# Altium schematic sheet [11]-JTAG.SchDoc
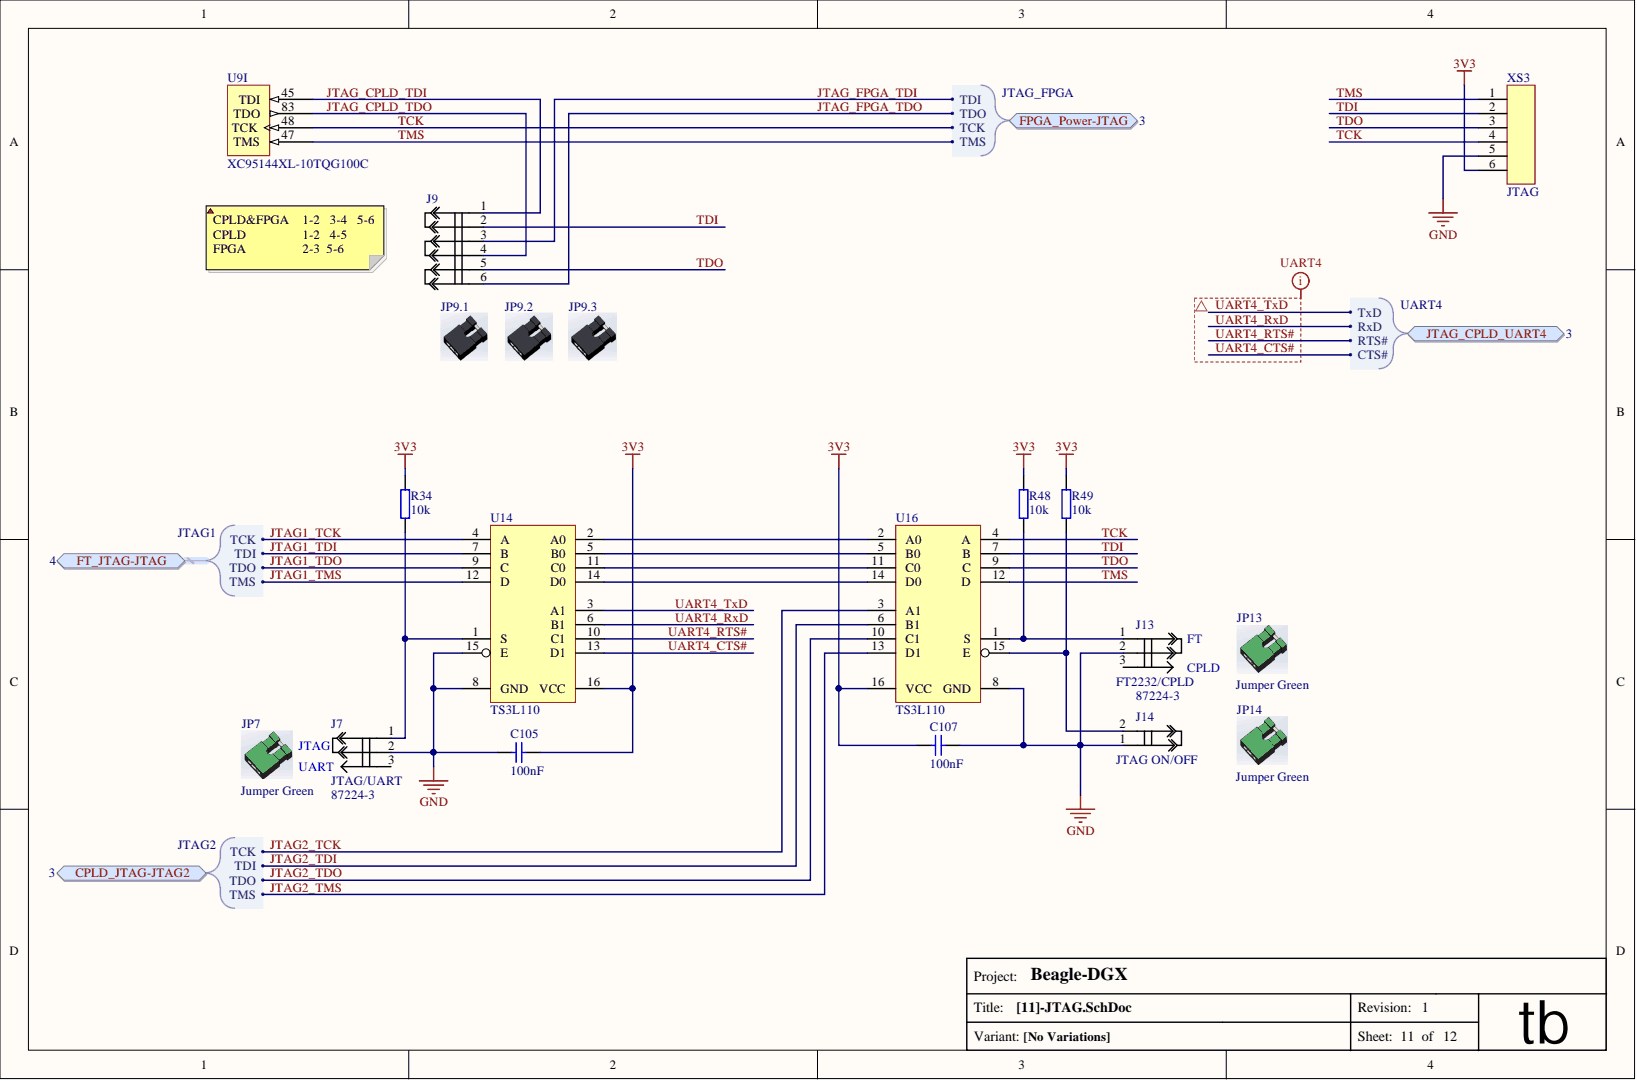
[diagram p0: [11]-JTAG.SchDoc]

## Parts
C105  value 100nF  [C0603]  (hidden comment: CAP)
C107  value 100nF  [C0603]  (hidden comment: CAP)
J7  JTAG/UART  value 87224-3  Manufacturer Part: 87224-3  [Jumper_3pin_green]
J9  jumper_6pin_3closed  [Jumper_6pin_3Closed_Black]  (value not drawn)
J13  FT2232/CPLD  value 87224-3  [Jumper_3pin_green]
J14  JTAG ON/OFF  [Jumper_2pin green]
JP7  value Jumper Green  Supplier Part: Jumper  (hidden comment: VCC_sel)  (mechanical)
JP9.1  (no value)  (mechanical)
JP9.2  (no value)  (mechanical)
JP9.3  (no value)  (mechanical)
JP13  value Jumper Green  Supplier Part: Jumper  (hidden comment: VCC_sel)  (mechanical)
JP14  value Jumper Green  Supplier Part: Jumper  (hidden comment: VCC_sel)  (mechanical)
R34  value 10k  [0603_RESISTOR]  (hidden comment: Resistor)
R48  value 10k  [0603_RESISTOR]  (hidden comment: Resistor)
R49  value 10k  [0603_RESISTOR]  (hidden comment: Resistor)
U9  XC95144XL-10TQG100C  [TQ100 - XC95144XL]  (part I)
U14  TS3L110  [TSSOP-16]
U16  TS3L110  [TSSOP-16]
XS3  JTAG  [Conn_6p]

## Ports
CPLD_JTAG-JTAG2, CPLD_JTAG-JTAG2.TCK, CPLD_JTAG-JTAG2.TDI, CPLD_JTAG-JTAG2.TDO, CPLD_JTAG-JTAG2.TMS, FPGA_Power-JTAG, FPGA_Power-JTAG.TCK, FPGA_Power-JTAG.TDI, FPGA_Power-JTAG.TDO, FPGA_Power-JTAG.TMS, FT_JTAG-JTAG, FT_JTAG-JTAG.TCK, FT_JTAG-JTAG.TDI, FT_JTAG-JTAG.TDO, FT_JTAG-JTAG.TMS, JTAG_CPLD_UART4, JTAG_CPLD_UART4.CTS#, JTAG_CPLD_UART4.RTS#, JTAG_CPLD_UART4.RxD, JTAG_CPLD_UART4.TxD

## Nets
3V3: C105.1 C107.1 R34.2 R48.2 R49.2 U14.16(VCC) U16.16(VCC) XS3.6
GND: C105.2 C107.2 J7.2 J13.2 J14.1 U14.8(GND) U14.15(E) U16.8(GND) XS3.5
JTAG1_TCK: U14.4(A) ; port FT_JTAG-JTAG
JTAG1_TDI: U14.7(B) ; port FT_JTAG-JTAG
JTAG1_TDO: U14.9(C) ; port FT_JTAG-JTAG
JTAG1_TMS: U14.12(D) ; port FT_JTAG-JTAG
JTAG2_TCK: U16.3(A1) ; port CPLD_JTAG-JTAG2
JTAG2_TDI: U16.6(B1) ; port CPLD_JTAG-JTAG2
JTAG2_TDO: U16.10(C1) ; port CPLD_JTAG-JTAG2
JTAG2_TMS: U16.13(D1) ; port CPLD_JTAG-JTAG2
JTAG_CPLD_TDI: J9.1 U9.45(TDI)
JTAG_CPLD_TDO: J9.4 U9.83(TDO)
JTAG_FPGA_TDI: J9.3 ; port FPGA_Power-JTAG
JTAG_FPGA_TDO: J9.6 ; port FPGA_Power-JTAG
TCK: U9.48(TCK) U16.4(A) XS3.4 ; port FPGA_Power-JTAG
TDI: J9.2 U16.7(B) XS3.2
TDO: J9.5 U16.9(C) XS3.3
TMS: U9.47(TMS) U16.12(D) XS3.1 ; port FPGA_Power-JTAG
UART4_CTS#: U14.13(D1) ; port JTAG_CPLD_UART4
UART4_RTS#: U14.10(C1) ; port JTAG_CPLD_UART4
UART4_RxD: U14.6(B1) ; port JTAG_CPLD_UART4
UART4_TxD: U14.3(A1) ; port JTAG_CPLD_UART4
NetJ7_1: J7.1 R34.1 U14.1(S)
NetJ13_1: J13.1 R48.1 U16.1(S)
NetJ14_2: J14.2 R49.1 U16.15(E)
NetU14_2: U14.2(A0) U16.2(A0)
NetU14_5: U14.5(B0) U16.5(B0)
NetU14_11: U14.11(C0) U16.11(C0)
NetU14_14: U14.14(D0) U16.14(D0)
N00474:

Not connected: J7 3; J13 3

## Library data of the parts
C105 C107 = CAP: Type of Component: Ceramic Capacitor; Component Kind: Standard; Package / Case: 0603; Library Name: RPI-VITA.IntLib
J7 = jumper_3pin: Manufacturer: TE Connectivity / AMP; Type of Component: Jumper; Component Kind: Standard; Manufacturer Link: http://www.te.com/usa-en/product-87224-3.html#pdp-related-products-inner; Package / Case: Header 1 x 3; Supplier: mouser; Supplier Link: http://www.mouser.ee/ProductDetail/TE-Connectivity-AMP/87224-3/?qs=sGAEpiMZZMs%252bGHln7q6pm48SVpWlpfsErguO%2fp0873w%3d; Supplier Part: 571-872243; Library Name: RPI-VITA.IntLib
J9 = jumper_6pin_3closed: Jumper 6 pin 3 Closed; Component Kind: Standard; Library Name: RPI-VITA.IntLib
J13 = jumper_3pin: Manufacturer: TE Connectivity / AMP; Manufacturer Part: 87224-3; Type of Component: Jumper; Value: 87224-3; Component Kind: Standard; Manufacturer Link: http://www.te.com/usa-en/product-87224-3.html#pdp-related-products-inner; Package / Case: Header 1 x 3; Supplier: mouser; Supplier Link: http://www.mouser.ee/ProductDetail/TE-Connectivity-AMP/87224-3/?qs=sGAEpiMZZMs%252bGHln7q6pm48SVpWlpfsErguO%2fp0873w%3d; Supplier Part: 571-872243; Library Name: RPI-VITA.IntLib
J14 = jumper_2pin: Component Kind: Standard; Library Name: RPI-VITA.IntLib
JP7 JP13 JP14 = jumper green: Manufacturer: Great Electric; Manufacturer Part: Jumper Cap Shunts Short Circuit Cap; Package / Case: 2.54mm; Supplier: aliexpress.com; Supplier Link: http://www.aliexpress.com/item/500pcs-lot-Asssorted-Color-2-54mm-Standard-Circuit-Board-Jumper-Cap-Shunts-Short-Circuit-Cap-CGKCH119/32344305619.html?spm=2114.03010208.3.80.nPPmoy&ws_ab_test=searchweb201556_1,searchweb201644_1_505_506_503_504_502_10001_10002_10017_10010_10005_10011_10006_10003_10004_10009_10007_10008,searchweb201560_5,searchweb1451318400_-1,searchweb1451318411_6452&btsid=16d11777-edde-4755-b8b5-9adacb495b09; Type of Component: Jumper; Library Name: RPI-VITA.IntLib
JP9.1-JP9.3 = jumper black: Library Name: RPI-VITA.IntLib
R34 R48 R49 = Resistor: Manufacturer: Vishay / Dale; Manufacturer Part: CRCW060310K0FKEA; Component Kind: Standard; Manufacturer Link: http://www.vishay.com/product?docid=20035; Package / Case: 0603; Supplier: aliexpress.com; Supplier Link: aliexpress.com/store/product/0603-SMD-Resistor-5-10K-ohm-5000pcs-lot/506373_32307351301.html?storeId=506373&spm=2114.12010208.100007.103.XAQnpm; Supplier Part: 0603 10k; Type of Component: Resistor; Library Name: RPI-VITA.IntLib
U9 = XC95144XL-10TQG100C: The XC95144XL is a 3.3V CPLD targeted for high-performance,  low-voltage applications in leading-edge communications  and computing systems.; Manufacturer: Xilinx Inc; Component Kind: Standard; Manufacturer Link: http://xgoogle.xilinx.com/search?output=xml_no_dtd&ie=UTF-8&oe=UTF-8&client=xilinx&proxystylesheet=xilinx&site=EntireSite&filter=0&num=200&sort=date:D:L:d1&getfields=*&show_dynamic_navigation=1&requiredfields=-Archived%3Atrue&q=XC95144XL-10TQG100C&as_q=; Manufacturer Part: XC95144XL-10TQG100C; Package / Case: TQG-100; Supplier 1: HK-Ada; Supplier 1 Part: XC95144XL-10TQG100C; Type of Component: CPLD; Value: XC95144XL-10TQG100C; Library Name: RPI-VITA.IntLib
U14 U16 = TS3L110: Switch ICs - Various Quad SPDT Hi-BW- T Lan Sw Diff; Manufacturer: TI; Component Kind: Standard; Manufacturer Part: TS3L110; Value: TS3L110; Library Name: RPI-VITA.IntLib
XS3 = conn_6p: PLS-6 2.54mm Pitch; Component Kind: Standard; Library Name: RPI-VITA.IntLib
